# Altium schematic sheet chip8_stm32_schm.SchDoc(chip8_stm32_schm)
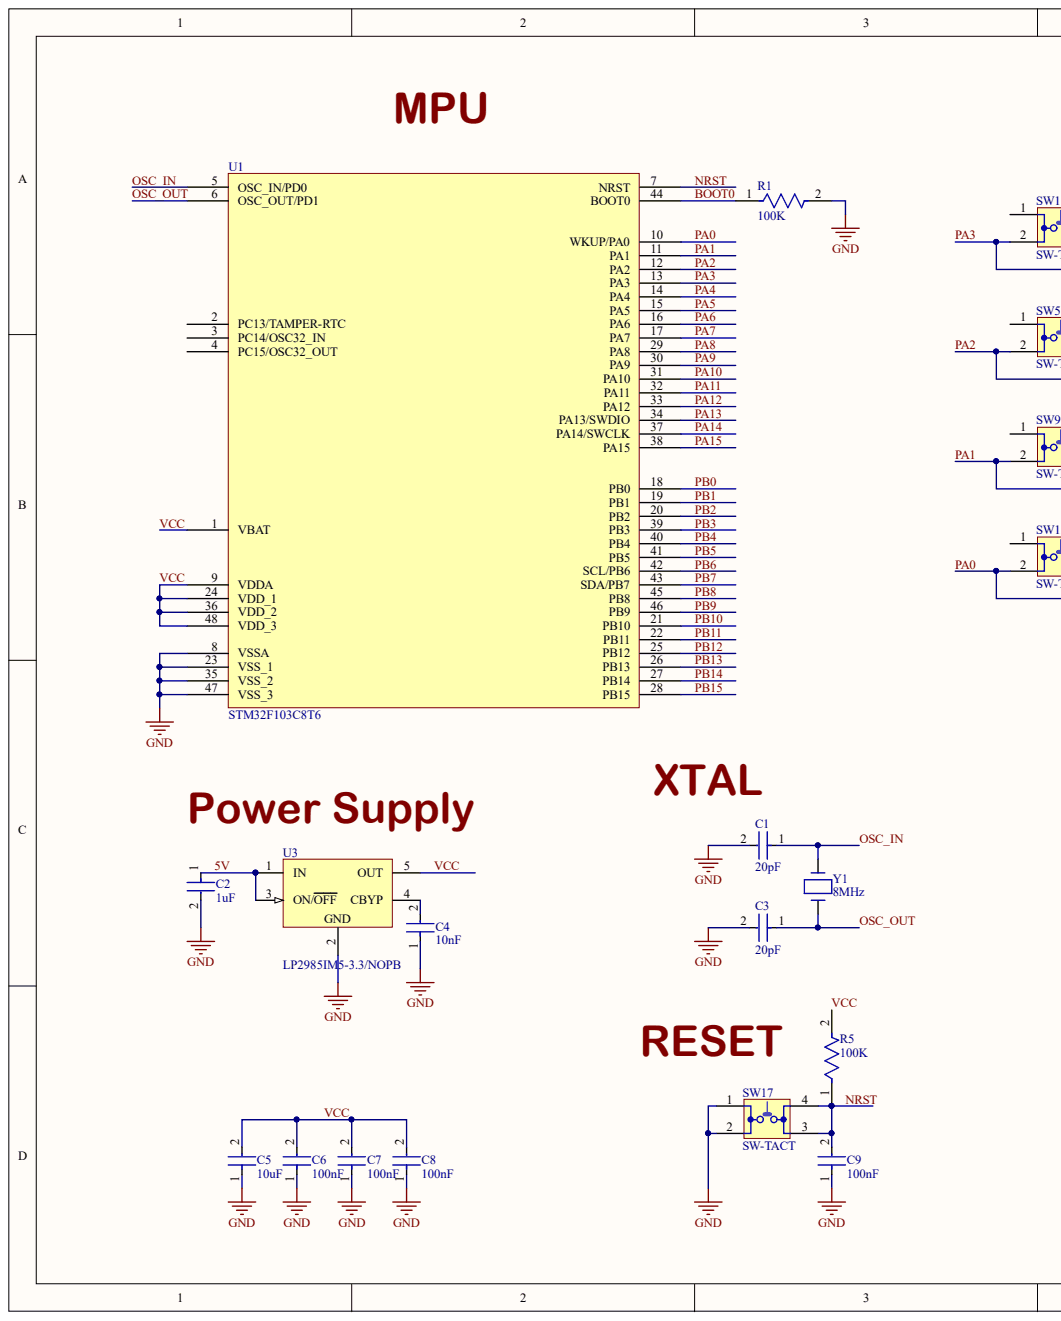
[diagram p0: chip8_stm32_schm.SchDoc, left]
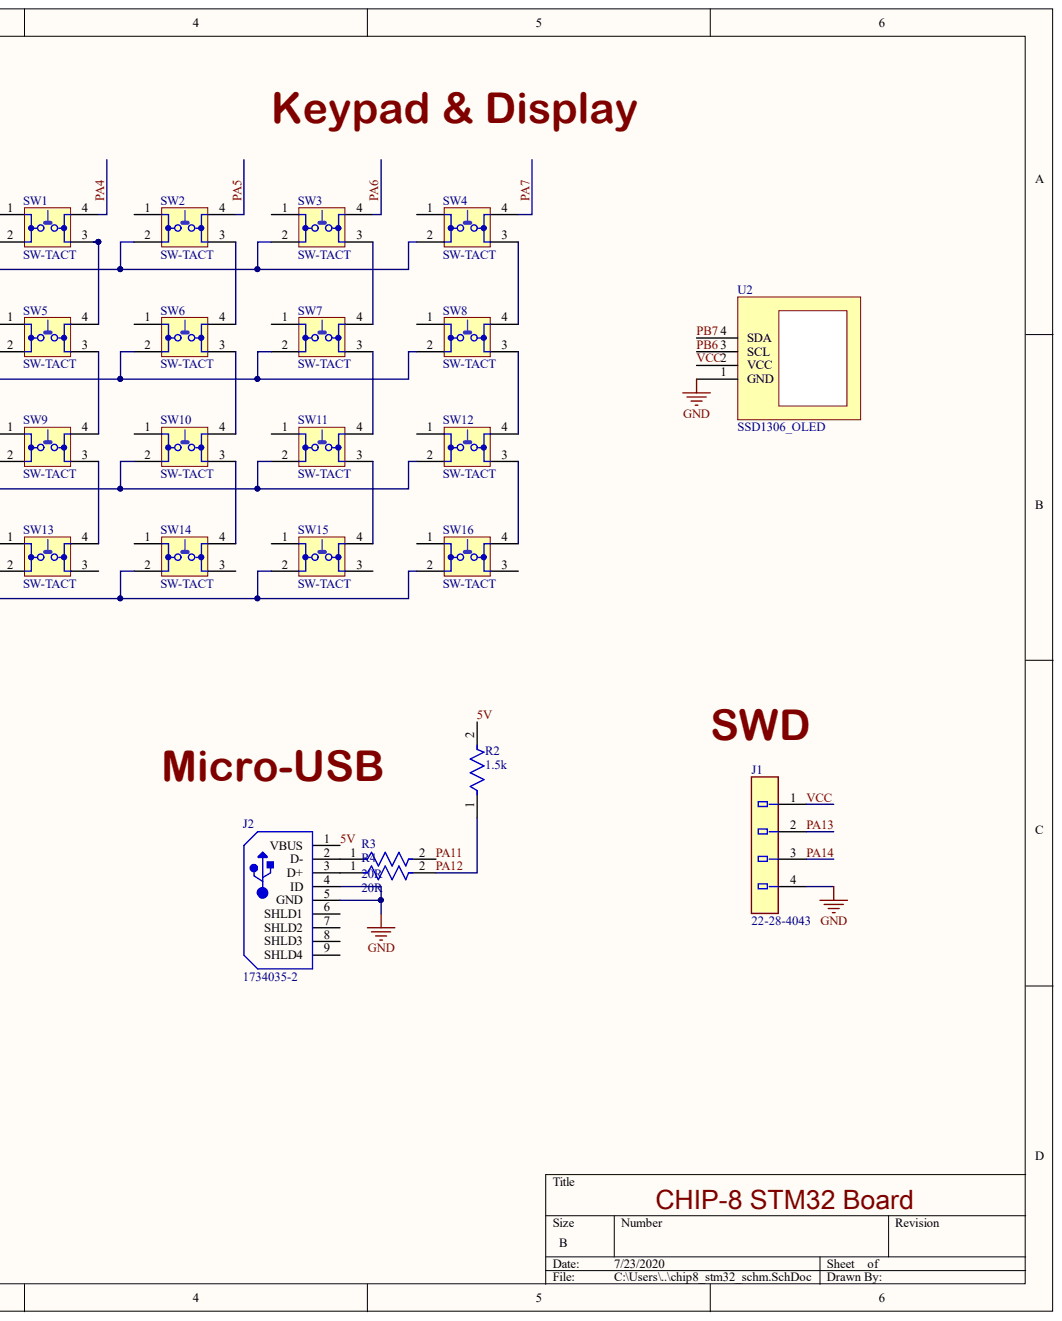
[diagram p1: chip8_stm32_schm.SchDoc, right]

## Parts
C1  20pF  [Yageo_CC0805-0.85_MFG]
C2  1uF  [Yageo_CC0805-0.85_MFG]
C3  20pF  [Yageo_CC0805-0.85_MFG]
C4  10nF  [Yageo_CC0805-0.85_MFG]
C5  10uF  [Yageo_CC0805-0.85_MFG]
C6  100nF  [Yageo_CC0805-0.85_MFG]
C7  100nF  [Yageo_CC0805-0.85_MFG]
C8  100nF  [Yageo_CC0805-0.85_MFG]
C9  100nF  [Yageo_CC0805-0.85_MFG]
J1  Part Number: 22-28-4043  [Molex_SD-42375-001_0]  (hidden comment: Conn_Molex_22-28-4043_eec)
J2  1734035-2  [TECO-1734035-2-5_V]
R1  100K  [TE_Connectivity_RP732A_MFG]
R2  1.5k  [TE_Connectivity_RP732A_MFG]
R3  20R  [TE_Connectivity_RP732A_MFG]
R4  20R  [TE_Connectivity_RP732A_MFG]
R5  100K  [TE_Connectivity_RP732A_MFG]
SW1  SW-TACT  [SW_1825910-6-4]
SW2  SW-TACT  [SW_1825910-6-4]
SW3  SW-TACT  [SW_1825910-6-4]
SW4  SW-TACT  [SW_1825910-6-4]
SW5  SW-TACT  [SW_1825910-6-4]
SW6  SW-TACT  [SW_1825910-6-4]
SW7  SW-TACT  [SW_1825910-6-4]
SW8  SW-TACT  [SW_1825910-6-4]
SW9  SW-TACT  [SW_1825910-6-4]
SW10  SW-TACT  [SW_1825910-6-4]
SW11  SW-TACT  [SW_1825910-6-4]
SW12  SW-TACT  [SW_1825910-6-4]
SW13  SW-TACT  [SW_1825910-6-4]
SW14  SW-TACT  [SW_1825910-6-4]
SW15  SW-TACT  [SW_1825910-6-4]
SW16  SW-TACT  [SW_1825910-6-4]
SW17  SW-TACT  [SW_1825910-6-4]
U1  STM32F103C8T6  [ST LQFP-48 7x7MM]
U2  SSD1306_OLED  [Molex_70543-0038_MFG]
U3  LP2985IM5-3.3/NOPB  [DBV0005A_L]
Y1  8MHz  [CTS_ATS080B_0]

## Nets
GND: C1.2 C2.2 C3.2 C4.1 C5.1 C6.1 C7.1 C8.1 C9.1 J1.4 J2.4(ID) J2.5(GND) R1.2 SW17.1 SW17.2 U1.8(VSSA) U1.23(VSS_1) U1.35(VSS_2) U1.47(VSS_3) U2.1(GND) U3.2
5V: C2.1 J2.1(VBUS) R2.2 U3.1(IN) U3.3(ON/OFF)
BOOT0: R1.1 U1.44(BOOT0)
NRST: C9.2 R5.1 SW17.3 SW17.4 U1.7(NRST)
OSC_IN: C1.1 U1.5(OSC_IN/PD0) Y1.2
OSC_OUT: C3.1 U1.6(OSC_OUT/PD1) Y1.1
PA0: SW13.2 SW14.2 SW15.2 SW16.2 U1.10(WKUP/PA0)
PA1: SW9.2 SW10.2 SW11.2 SW12.2 U1.11(PA1)
PA2: SW5.2 SW6.2 SW7.2 SW8.2 U1.12(PA2)
PA3: SW1.2 SW2.2 SW3.2 SW4.2 U1.13(PA3)
PA4: SW1.4 U1.14(PA4)
PA5: SW2.4 U1.15(PA5)
PA6: SW3.4 U1.16(PA6)
PA7: SW4.4 U1.17(PA7)
PA8: U1.29(PA8)
PA9: U1.30(PA9)
PA10: U1.31(PA10)
PA11: R3.2 U1.32(PA11)
PA12: R2.1 R4.2 U1.33(PA12)
PA13: J1.2 U1.34(PA13/SWDIO)
PA14: J1.3 U1.37(PA14/SWCLK)
PA15: U1.38(PA15)
PB0: U1.18(PB0)
PB1: U1.19(PB1)
PB2: U1.20(PB2)
PB3: U1.39(PB3)
PB4: U1.40(PB4)
PB5: U1.41(PB5)
PB6: U1.42(SCL/PB6) U2.3(SCL)
PB7: U1.43(SDA/PB7) U2.4(SDA)
PB8: U1.45(PB8)
PB9: U1.46(PB9)
PB10: U1.21(PB10)
PB11: U1.22(PB11)
PB12: U1.25(PB12)
PB13: U1.26(PB13)
PB14: U1.27(PB14)
PB15: U1.28(PB15)
VCC: C5.2 C6.2 C7.2 C8.2 J1.1 R5.2 U1.1(VBAT) U1.9(VDDA) U1.24(VDD_1) U1.36(VDD_2) U1.48(VDD_3) U2.2(VCC) U3.5(OUT)
NetC4_2: C4.2 U3.4(CBYP)
NetJ2_2: J2.2(D-) R3.1
NetJ2_3: J2.3(D+) R4.1
NetSW1_3: SW1.3 SW5.4
NetSW2_3: SW2.3 SW6.4
NetSW3_3: SW3.3 SW7.4
NetSW4_3: SW4.3 SW8.4
NetSW5_3: SW5.3 SW9.4
NetSW6_3: SW6.3 SW10.4
NetSW7_3: SW7.3 SW11.4
NetSW8_3: SW8.3 SW12.4
NetSW9_3: SW9.3 SW13.4
NetSW10_3: SW10.3 SW14.4
NetSW11_3: SW11.3 SW15.4
NetSW12_3: SW12.3 SW16.4

Not connected: J2 6(SHLD1) 7(SHLD2) 8(SHLD3) 9(SHLD4); SW1 1; SW2 1; SW3 1; SW4 1; SW5 1; SW6 1; SW7 1; SW8 1; SW9 1; SW10 1; SW11 1; SW12 1; SW13 1 3; SW14 1 3; SW15 1 3; SW16 1 3; U1 2(PC13/TAMPER-RTC) 3(PC14/OSC32_IN) 4(PC15/OSC32_OUT)

## Library data of the parts
C1 C3 = C20pF: Component Kind: Standard; Library Name: chip8_stm32_schlib.SchLib
C2 = C1_uF: Component Kind: Standard; Library Name: chip8_stm32_schlib.SchLib
C4 = C10nF: Component Kind: Standard; Library Name: chip8_stm32_schlib.SchLib
C5 = C10uF: Component Kind: Standard; Library Name: chip8_stm32_schlib.SchLib
C6-C9 = C100nF: Component Kind: Standard; Library Name: chip8_stm32_schlib.SchLib
J1 = Conn_Molex_22-28-4043_eec: CONN HEADER 4POS .100 VERT GOLD; Keying_to_Mating_Part: None; Manufacturer: Molex; Old_Part_Number: 42375-0039; Breakaway: Yes; Category 75Fab8F04590Ae3D: Connectors and Adapters; Category 0836C53C3D42D3F1: Connectors; Category E7012A0Bd22E3Cc6: Headers & Receptacles; Circuits_Loaded: 4; Color___Resin: Black; contact_material: Brass; CSA: LR19980; Current___Maximum_per_Contact: 4.0A; current_rating: 4 A; Durability_mating_cycles_max: 100; Duration_at_Max_Process_Temperature_seconds: 005; First_Mate__Last_Break: No; gender: Male; glow_wire_compliant: Non-Compliant; Lead_freeProcess_Capability: WAVE; Material___Plating_Termination: Tin; Material___Resin: High Temperature Thermoplastic; Max_Cycles_at_Max_Process_Temperature: 001; Mfg Package Ident: SD-42375-001; Net_Weight: 0.244/g; number_of_rows: 1; Overview: KK® Interconnect System - Molex; Packaging_Type: Bag; PC_Tail_Length: 3.18mm; PCB_Thickness___Recommended: 1.60mm; pin_pitch: 2.54 mm; Pitch___Mating_Interface: 2.54mm; Plating_min___Mating: 0.381μm; Plating_min___Termination: 2.540μm; Process_Temperature_max_C: 260; Product_Name: KK® 254; Product_Specification: PS-10-07; size_height: 2.29 mm; size_length: 10.16 mm; size_width: 2.49 mm; Temperature_Range___Operating: See Product Specification; Termination_Interface_Style: Through Hole; UL: E29179; UPC: 800754386197; Voltage: 250V; voltage_rating_dc: 250 V; 3D_model: http://www.molex.com/pdm_docs/stp/22-28-4043_stp.zip; Application: Board-to-Board, Signal, Wire-to-Board; Category: PCB Headers; Circuits_maximum: 4; color: Black; Component Kind: Standard; ComponentLink1Description: Upverter; ComponentLink1URL: https://upverter.com/upn/revision/1b2cd2f48c261c21/; ComponentLink2Description: Datasheet; ComponentLink2URL: http://www.molex.com/pdm_docs/sd/022284043_sd.pdf; contact_plating: Gold; Digikey Description: CONN HEADER 4POS .100 VERT GOLD; Digikey Part Number: WM50016-04-ND; Flammability: 94V-0; flammability_rating: UL 94 V-0; Glow_Wire_Compliant: No; Guide_to_Mating_Part: No; halogen_free_status: Not Low Halogen; helpURL: https://upverter.com/upn/revision/1b2cd2f48c261c21/; housing_color: Black; Ipc Land Pattern Name: HDRV4W66P254_1X4_1016X66X457; Lead Free: yes; Library Name: chip8_stm32_schlib.SchLib; Lock_to_Mating_Part: None; Material___Metal: Brass; Material___Plating_Mating: Gold; Mounting Type: through hole; Mouser Part Number: 538-22-28-4043; number_of_circuits: 4; number_of_contacts: 4; number_of_positions: 4; Number_of_Rows: 1; Num Pins: 4; Octopart Part Number: 44448d4a5f9d9b83; Orientation: Vertical; orientation: Vertical; Package: SD-42375-001; part_page: http://www.molex.com/molex/products/datasheet.jsp?part=active/0022284043_PCB_HEADERS.xml; PCB_Locator: No; PCB_Retention: None; Polarized_to_Mating_Part: No; Polarized_to_PCB: No; RoHS: TRUE; Sales_Drawing: SD-42375-001; Series: 42375; Shrouded: No; Stackable: No; Supplier 1: Digi-Key; Supplier 2: Mouser; Supplier Part Number 1: WM50016-04-ND; Supplier Part Number 2: 538-22-28-4043; Surface_Mount_Compatible_SMC: Yes; Temperature: -40°C to +80°C; Temperature Range High: +80°C; Temperature Range Low: -40°C; Type: connector; Voltage___Maximum: 250V
J2 = 1734035-2: Surface Mount Type B Mini USB, 5 Positions, 0.8 mm Pitch, 0 to 50 degC, RoHS, Tape and Reel; Manufacturer: TE Connectivity; Body Orientation: RightAngle; Gender: RCP; Number of Contacts: 5; Number of Ports: 1; Pitch: 0.8mm; Color: Black; Component Kind: Standard; Contact Material: PhosphorBronze; Contact Plating: GoldOverNickel; Datasheet URL: https://datasheet.ciiva.com/11759/0900766b80ed62f8-11759638.pdf; Datasheet Version: Rev. B1, 07/2010; Dimension: 4.14mm; Housing Material: Thermoplastic; Library Name: chip8_stm32_schlib.SchLib; Manufacturer URL: http://www.te.com/; Maximum Current Rating: N/A; Maximum Voltage Rating: N/A; Mounting: SurfaceMount; Number of Terminals: 5; Operating Temperature: N/A; Packaging: TapeandReel; Part No: 1734035-2; PartNumber: 1734035-2; RoHS: Yes; Termination Method: Solder; Type: USB
R1 R5 = R100K: Component Kind: Standard; Library Name: chip8_stm32_schlib.SchLib
R2 = R1.5K: Component Kind: Standard; Library Name: chip8_stm32_schlib.SchLib
R3 R4 = R20R: Component Kind: Standard; Library Name: chip8_stm32_schlib.SchLib
U1 = STM32F103C8T6: ARM microcontroller; Flash: 64kB; 72MHz; SRAM: 20kB; LQFP48; Manufacturer: STMicroelectronics; Interface: CAN; Kind of architecture: Cortex M3; MinAmount: 1; Number of 16bit timers: 4; Number of inputs/outputs: 37; SuppliedAmount: 0; Supply voltage: 2...3.6V DC; Unit: pcs; Case: LQFP48; Category: ST microcontrollers; Clock frequency: 72MHz; Component Kind: Standard; ComponentLink1Description: http://www.tme.eu/en/details/stm32f103c8t6/st-microcontrollers/stmicroelectronics/; ComponentLink1URL: http://www.tme.eu/en/details/stm32f103c8t6/st-microcontrollers/stmicroelectronics/; Flash memory capacity: 64kB; Library Name: chip8_stm32_schlib.SchLib; Manufacturer Part Number: STM32F103C8T6; Mounting: SMD; Multiples: 1; Price Type: NET; Pricing 1: 1=5.45, 5=5, 25=4.5, 100=3.38 (USD); SRAM memory capacity: 20kB; Stock 1: 860; Supplier 1: TME; Supplier Part Number 1: STM32F103C8T6; Type of integrated circuit: ARM microcontroller; Weight: 0.19
U2 = SSD1306: Component Kind: Standard; Library Name: chip8_stm32_schlib.SchLib
U3 = LP2985IM5-3.3/NOPB: Micropower 150 mA Low-Noise Ultra Low-Dropout Regulator, 5-pin SOT-23, Pb-Free; Manufacturer: Texas Instruments; CompType: LDO IC; PackageTopMarking: L0RB*XTT; PowerWise: N; Component Kind: Standard; ComponentLink1Description: Datasheet URL; ComponentLink1URL: http://www.ti.com/general/docs/lit/getliterature.tsp?genericPartNumber=LP2985-N&fileType=pdf; Library Name: chip8_stm32_schlib.SchLib; PackageDescription: Molded SOT-23, .115 x .063 x .040 in Body, 5 Lead, .0375 in Pitch; PackageReference: DBV0005A; PinCount: 5; RoHS: Y
Y1 = Crystal8MHz: Component Kind: Standard; Library Name: chip8_stm32_schlib.SchLib
SW1-SW17 = SW-TACT: Component Kind: Standard; Library Name: chip8_stm32_schlib.SchLib
